# Altium schematic sheet Stepper_Driver.SchDoc
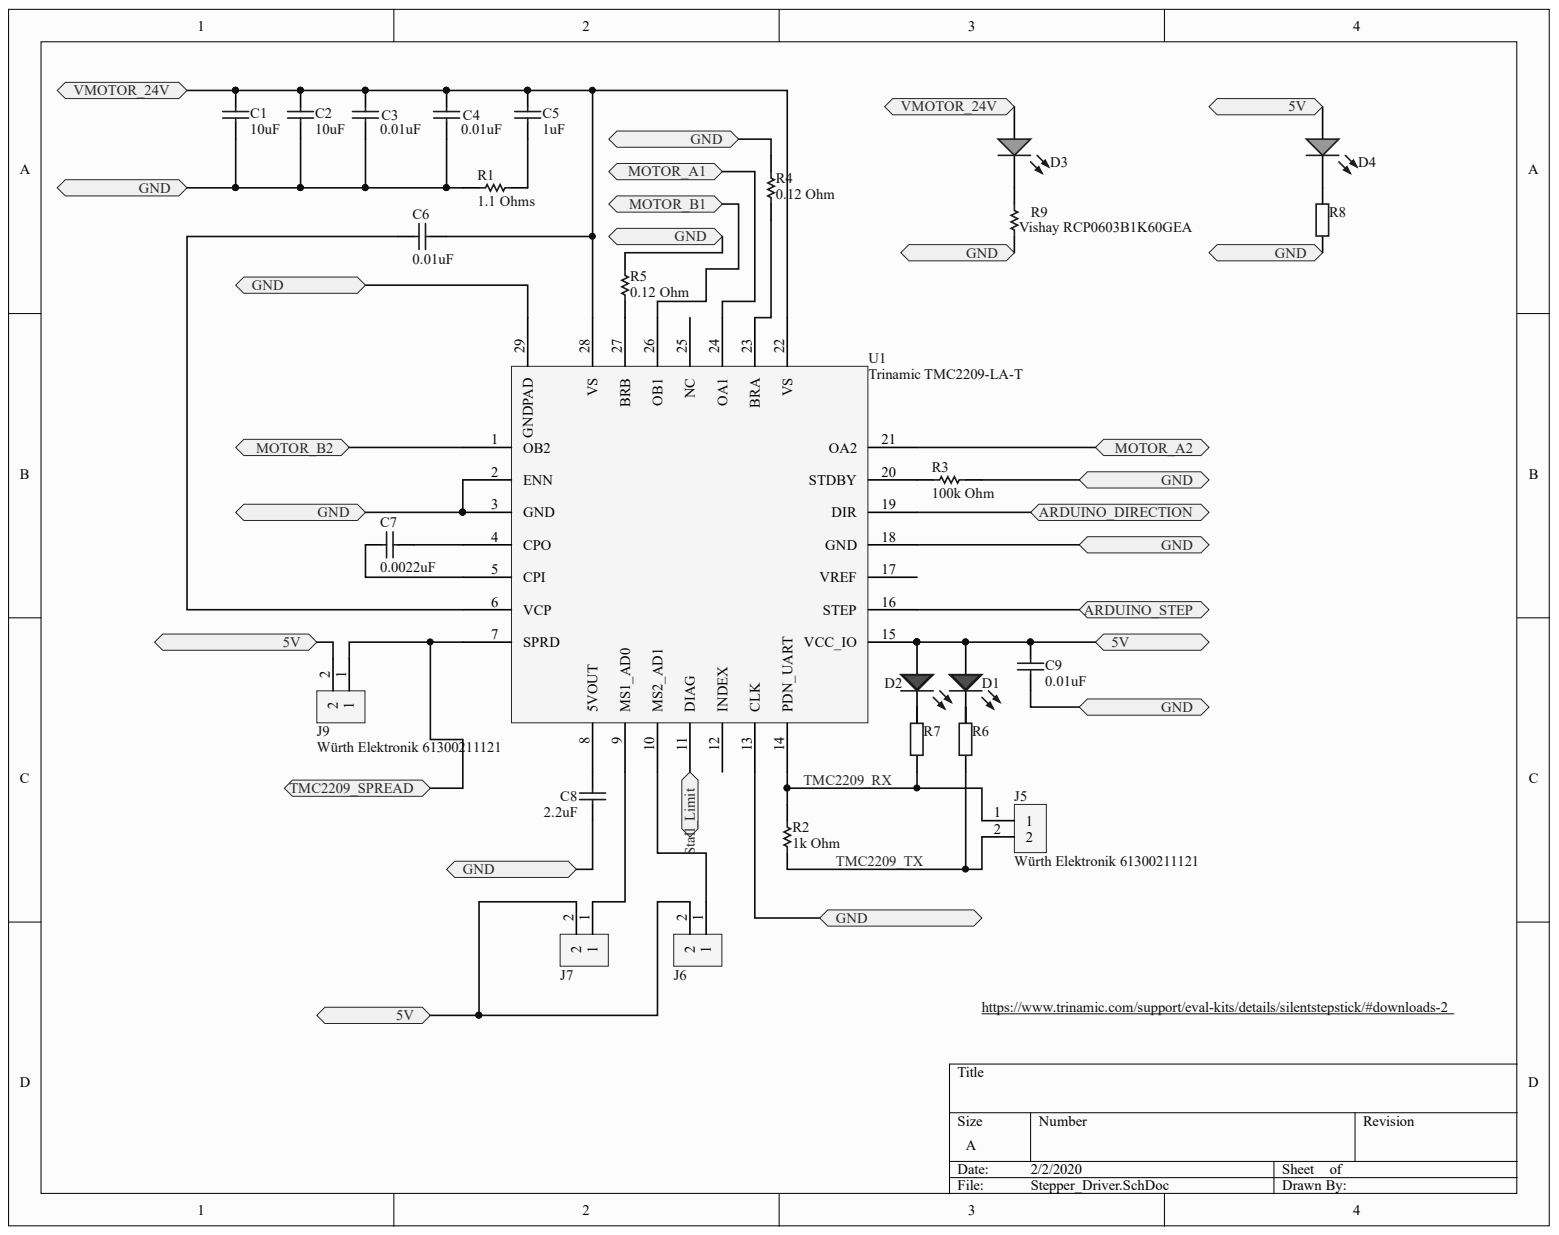
[diagram p0: Stepper_Driver.SchDoc]

## Parts
C1  10uF  [PCB-fjsvh2tjaqovriebo4bq-1]
C2  10uF  [PCB-fjsvh2tjaqovriebo4bq-1]
C3  0.01uF  [CAPC1608X87X35NL15T15]
C4  0.01uF  [CAPC1608X87X35NL15T15]
C5  1uF  [PCB-hxapk9n2qzqgg8bo7kac-1]
C6  0.01uF  [CAPC1608X87X35NL15T15]
C7  0.0022uF  [CAPC2013X145X50NL20T25]
C8  2.2uF  [PCB-by9mfsx1d7doro4kgscm-1]
C9  0.01uF  [CAPC1608X87X35NL15T15]
D1  150060RS75000  [PCB-57zpj2ao5h5qcpth1dzy-1]  (value not drawn)
D2  150060RS75000  [PCB-57zpj2ao5h5qcpth1dzy-1]  (value not drawn)
D3  150060VS75000  [0603_A]  (value not drawn)
D4  150060VS75000  [0603_A]  (value not drawn)
J5  Würth Elektronik 61300211121  [PCB-omgsbkhp90n7lpri88og-1]
J6  Würth Elektronik 61300211121  [PCB-omgsbkhp90n7lpri88og-1]
J7  Würth Elektronik 61300211121  [PCB-omgsbkhp90n7lpri88og-1]
J9  Würth Elektronik 61300211121  [PCB-omgsbkhp90n7lpri88og-1]
R1  1.1 Ohms  [RESC1608X55X30ML20T10]
R2  1k Ohm  [SMD0603GRID25PAD90]
R3  100k Ohm  [RESC1608X50X30LL20T20]
R4  0.12 Ohm  [PCB-r1zjm8dwx2y0hjgoforr-1]
R5  0.12 Ohm  [PCB-r1zjm8dwx2y0hjgoforr-1]
R6  Res2  [PCB-6qp2qqoyvohxfj5se87m-1]  (value not drawn)
R7  Res2  [PCB-6qp2qqoyvohxfj5se87m-1]  (value not drawn)
R8  Res2  [PCB-6qp2qqoyvohxfj5se87m-1]  (value not drawn)
R9  Vishay RCP0603B1K60GEA  [PCB-ajdzuyhj1ll9rvlulscs-1]
U1  Trinamic TMC2209-LA-T  Manufacturer Part Number: TMC2209-LA-T  [QFN50P500X500X85_HS-29N]

## Ports
5V, ARDUINO_DIRECTION, ARDUINO_STEP, GND, MOTOR_A1, MOTOR_A2, MOTOR_B1, MOTOR_B2, STALL_LIMIT, TMC2209_SPREAD, VMOTOR_24V

## Nets
STALL_LIMIT: U1.11(DIAG) ; port STALL_LIMIT
5V: C9.2 D1.2 D2.2 D4.2 J6.2 J7.2 J9.2 U1.15(VCC_IO) ; port 5V
ARDUINO_DIRECTION: U1.19(DIR) ; port ARDUINO_DIRECTION
ARDUINO_STEP: U1.16(STEP) ; port ARDUINO_STEP
GND: C1.2 C2.2 C3.2 C4.2 C8.2 C9.1 R1.1 R3.2 R4.1 R5.1 R8.2 R9.2 U1.2(ENN) U1.3(GND) U1.13(CLK) U1.18(GND) U1.29(GNDPAD) ; port GND
MOTOR_A1: U1.24(OA1) ; port MOTOR_A1
MOTOR_A2: U1.21(OA2) ; port MOTOR_A2
MOTOR_B1: U1.26(OB1) ; port MOTOR_B1
MOTOR_B2: U1.1(OB2) ; port MOTOR_B2
TMC2209_RX: J5.1 R2.1 R7.2 U1.14(PDN_UART)
TMC2209_SPREAD: J9.1 U1.7(SPRD) ; port TMC2209_SPREAD
TMC2209_TX: J5.2 R2.2 R6.2
VMOTOR_24V: C1.1 C2.1 C3.1 C4.1 C5.1 C6.1 D3.2 U1.22(VS) U1.28(VS) ; port VMOTOR_24V
NetC5_2: C5.2 R1.2
NetC6_2: C6.2 U1.6(VCP)
NetC7_1: C7.1 U1.5(CPI)
NetC7_2: C7.2 U1.4(CPO)
NetC8_1: C8.1 U1.8(5VOUT)
NetD1_1: D1.1 R6.1
NetD2_1: D2.1 R7.1
NetD3_1: D3.1 R9.1
NetD4_1: D4.1 R8.1
NetJ6_1: J6.1 U1.10(MS2_AD1)
NetJ7_1: J7.1 U1.9(MS1_AD0)
NetR3_1: R3.1 U1.20(STDBY)
NetR4_2: R4.2 U1.23(BRA)
NetR5_2: R5.2 U1.27(BRB)

Not connected: U1 12(INDEX) 17(VREF) 25(NC)

## Library data of the parts
C1 C2 = CMP-84d84c8150e65b1f-1: Cap , mlcc , 1210, 50V , x5R, 10Uf+/-20% , t&r Rohs Compliant: Yes; Manufacturer Part Number: UMK325BJ106MM-P; Case/Package: 1210; Component Kind: Standard; Library Name: CommunityVault; Lifecycle Status: Not Listed by Manufacturer; Mounting Style: Surface Mount; OctopartID: 84d84c8150e65b1f; Octopart URL: https://octopart.com/umk325bj106mm-p-taiyo+yuden-22278488; Packaging: Tape & Reel (TR); RoHS: Compliant
C3 C4 C6 C9 = CMP-143989-4: Cap Ceramic 0.01uF 50V X7R 20% SMD 0603 125C Paper T/R; Manufacturer: KEMET; Manufacturer Part Number: C0603C103M5RACTU; Capacitance: 0.01uF; Component Kind: Standard; Library Name: CommunityVault; OctopartID: 4bf782fc161e9430
C5 = CMP-f7023f64287128c5-2: Cap Ceramic 1uF 50V X5R 10% SMD 0603 85°C Paper T/R; Case/Package: 0603; Component Kind: Standard; Library Name: CommunityVault; Mounting Style: Surface Mount
C7 = CMP-8752370-2: Cap Ceramic 0.0022uF 50V X7R 10% SMD 0805 125C Paper T/R; Manufacturer Part Number: C0805C222K5RACTU; Capacitance: 2.2e-09 F; Lead-Free Status: R; Voltage Rating (DC): 50.0 V; Capacitance Tolerance: ±10%; Case/Package: 0805; Component Kind: Standard; Dielectric Characteristic: X7R; Dielectric Material: Ceramic; Library Name: CommunityVault; Mounting Style: Surface Mount; OctopartID: f81d312c65a227da; Octopart Url: https://octopart.com/c0805c222k5rac7800-kemet-3913945; RoHS: Compliant
C8 = CMP-8cd4478efca15313-2: Cap Ceramic 2.2uF 50V X5R 10% SMD 0603 85°C Paper T/R; Manufacturer Part Number: GRM188R61H225KE11D; Capacitance: 2.20 µF; Case/Package (SI): 1608; Voltage Rating (DC): 50.0 V; Component Kind: Standard; Dielectric Characteristic: X5R; Library Name: CommunityVault; OctopartID: 8cd4478efca15313; Octopart URL: http://octopart.com/grm188r61h225ke11d-murata-38819091; Packaging: Cut Tape (CT); RoHS: Compliant; Simulation: GRM188R61H225ME11D; Size-Length: 1.60 mm; Size-Thickness: 800 µm; Size-Width: 800 µm
D1 D2 = CMP-12518859-14: Standard LEDs - SMD WL-SMCW SMDMono TpVw Waterclr 0603 Red; Case/Package alternativee: 1206; Component Kind: Standard; Library Name: CommunityVault; RoHS: Compliant
D3 D4 = CMP-12518862-3: SMD mono-color Chip LED, WL-SMCW, Bright Green; Forward Voltage: 2.0 V; Luminous Intensity: 0.04 cd; Viewing Angle: 140 °; Component Kind: Standard; Library Name: CommunityVault; Manufacturer Part Number: 150060VS75000; OctopartID: 8ccb4404dcbf530c; Octopart Url: https://octopart.com/150060vs75000-w%C3%BCrth+elektronik-29717778; REACH SVHC Compliance: No SVHC; RoHS: Compliant
J5-J7 J9 = CMP-748fbcb73d6bab48-27: THT Vertical Pin Header WR-PHD, Pitch 2.54 mm, Single Row, 2 pins; Contact Resistance: 20.0 mΩ; Current Rating: 3.00 A; Dielectric Withstanding Voltage: 500 V; Gender: Male; Lead-Free Status: Lead Free; Number of Contacts: 2; Operating Voltage: 250 V; Component Kind: Standard; Contact Material: Copper; Contact Plating: Gold; Library Name: CommunityVault; Manufacturer Part Number: 61300211121; Mounting Style: Through Hole; Number of Pins: 2; OctopartID: 748fbcb73d6bab48; Operating Temperature: -40.0 °C (min); Orientation: Vertical; Packaging: Bag; REACH SVHC Compliance: No SVHC; RoHS: Compliant
R1 = CMP-3691192-2: Thick Film Resistors - SMD 1/10watts 1.1ohms 1%; Manufacturer Part Number: RK73H1JTTD1R10F; Lead-Free Status: Lead Free; Power Rating: 0.1 W; Resistance: 1.1 Ω; Temperature Coefficient: 100.0 ppm/°C; Voltage Rating (DC): 50.0 V; Component Kind: Standard; Library Name: CommunityVault; Mounting Style: Surface Mount; Number of Pins: 2; OctopartID: 6547ac6c4f7dba80; Octopart Url: https://octopart.com/rk73h1jttd1r10f-koa+speer-2166620; Resistance Tolerance: ±1%; RoHS: Compliant; Size-Length: 0.0016 m; Size-Width: 0.0008 m
R2 = CMP-68a0a2e72c4eb767-17: RC Series 0603 0.1 W 1 kOhms 5% ±100 ppm/°C SMT Thick Film Chip Resistor; Manufacturer Part Number: RC0603JR-071KL; Lead-Free Status: Lead Free; Power Rating: 100 mW; Resistance: 1.00 kΩ; Voltage Rating (DC): 50.0 V; Component Kind: Standard; Library Name: CommunityVault; Mounting Style: Surface Mount; OctopartID: 68a0a2e72c4eb767; Octopart Url: http://octopart.com/rc0603jr-071kl-yageo-55402888; Packaging: Cut Tape (CT), Tape & Reel (TR); REACH SVHC Compliance: No SVHC; Resistance Tolerance: ±5%; RoHS: Compliant; Seller Landing  Url: https://octopart-clicks.com/click/track?country=US&ak=8157f166&sig=04f502c&sid=1106&ppid=55402888&vpid=198667460&ct=offers; Size-Height: 450 µm; Size-Length: 1.60 mm; Size-Width: 800 µm
R3 = CMP-1864562-2: RES SMD 100K OHM 0.5% 1/16W 0816; Manufacturer Part Number: RR0816P-104-D; Lead-Free Status: Lead Free; Power Rating: 0.063 W; Resistance: 100000.0 Ω; Voltage Rating (DC): 75.0 V; Component Kind: Standard; Library Name: CommunityVault; Mounting Style: Surface Mount; Number of Pins: 2; OctopartID: 86394f3d5ac959fe; Octopart Url: https://octopart.com/rr0816p-104-d-susumu-981398; Resistance Tolerance: ±0.5%; RoHS: Compliant; Size-Height: 0.0004 m; Size-Length: 0.0016 m; Size-Width: 0.0008 m
R4 R5 = CMP-21e9ebaea997fe2e-1: Res Thick Film 0603 0.12 Ohm 5% 1/10W ±300ppm/°C Molded SMD Punched Carrier T/R; Manufacturer Part Number: ERJ-3RSJR12V; Case/Package (SI): 1608; Lead-Free Status: Lead Free; Power Rating: 100 mW; Resistance: 120 m?; Temperature Coefficient: -300 ppm/°C to 300 ppm/°C; Case/Package: 0603; Component Kind: Standard; Library Name: CommunityVault; Lifecycle Status: Not Listed by Manufacturer; Mounting Style: Surface Mount; OctopartID: 21e9ebaea997fe2e; Octopart URL: https://octopart.com/erj-3rsjr12v-panasonic-55422232; Operating Temperature: 125 °C (max); Resistance Tolerance: ±5%; RoHS: Compliant; Size-Height: 450 µm; Size-Length: 1.60 mm; Size-Width: 800 µm
R6-R8 = CMP-b10479cbe7db6ec3-1: Surface Mount Thick Film Resistor, Crg Series, 330 Ohm, 200 Mw, - 1%, 50 V, 0603 [1608 Metric]; Manufacturer Part Number: CRGH0603F330R; Case/Package (SI): 1608; ELV Compliant: Compliant; Power Rating: 200 mW; Temperature Coefficient: -100 ppm/°C to 100 ppm/°C; Voltage Rating (DC): 50.0 V; Case/Package: 0603, 1608; Component Kind: Standard; Library Name: CommunityVault; Lifecycle Status: Not Listed by Manufacturer; Mounting Style: Surface Mount; OctopartID: b10479cbe7db6ec3; Packaging: Tape & Reel (TR); Resistance Tolerance: ±1%
R9 = CMP-b43f7909d1316bf8-1: Res Thkflm Alum Nitride 0603 1.6K Ohm 2% 100PPM Std Term SMD; Component Kind: Standard; Library Name: FavoritesLibrary; Manufacturer Part Number: RCP0603B1K60GEA; OctopartID: b43f7909d1316bf8; Octopart URL: https://octopart.com/rcp0603b1k60gea-vishay-71057512
U1 = CMP-333afc2d4935ecfd-4: Motor Driver, Stepper, QFN-28; Component Kind: Standard; Library Name: CommunityVault; OctopartID: 333afc2d4935ecfd; Octopart URL: https://octopart.com/tmc2209-la-t-trinamic-102588265
